# Altium schematic sheet 7404337_RZ_G2.SchDoc
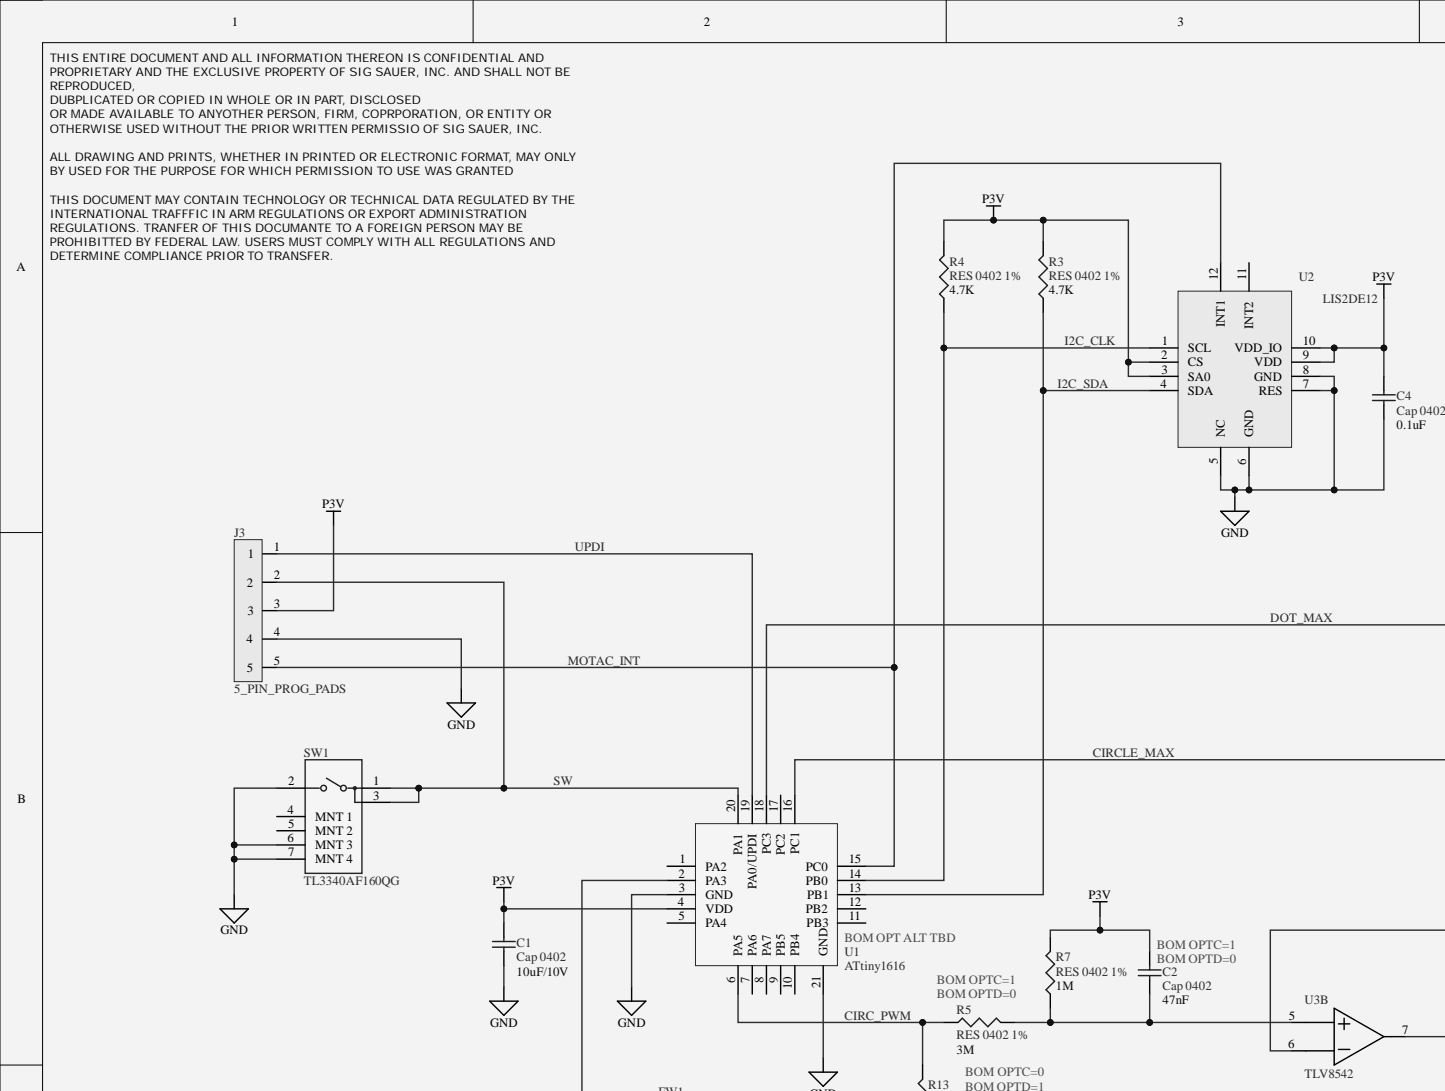
[diagram p0: 7404337_RZ_G2.SchDoc, top left]
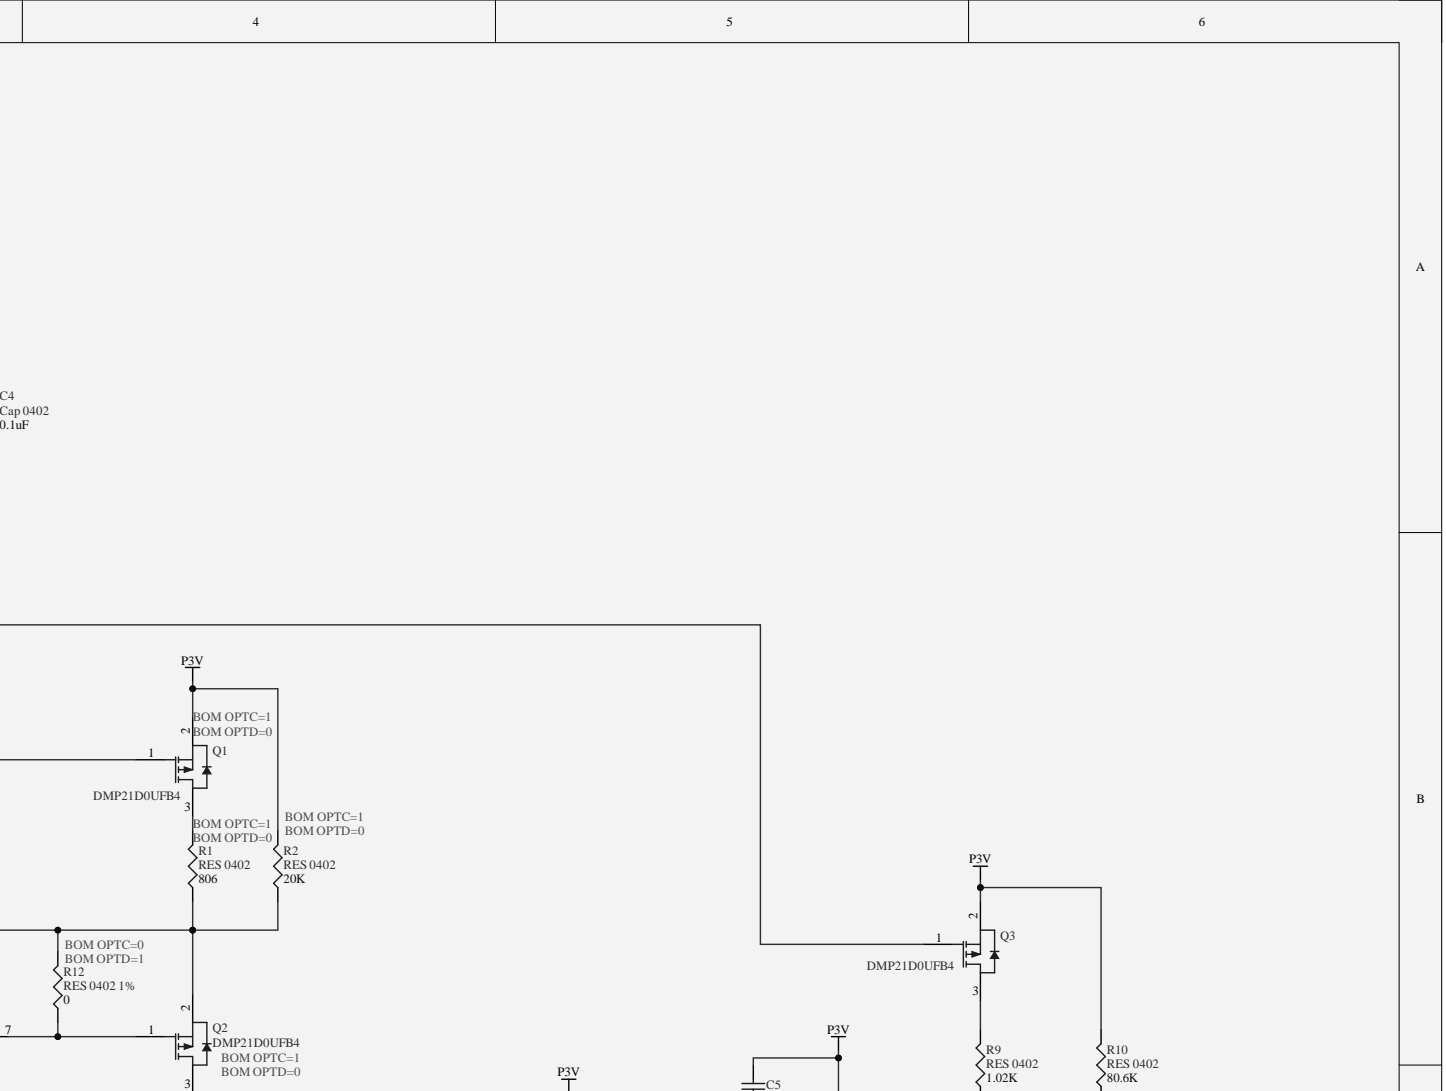
[diagram p1: 7404337_RZ_G2.SchDoc, top right]
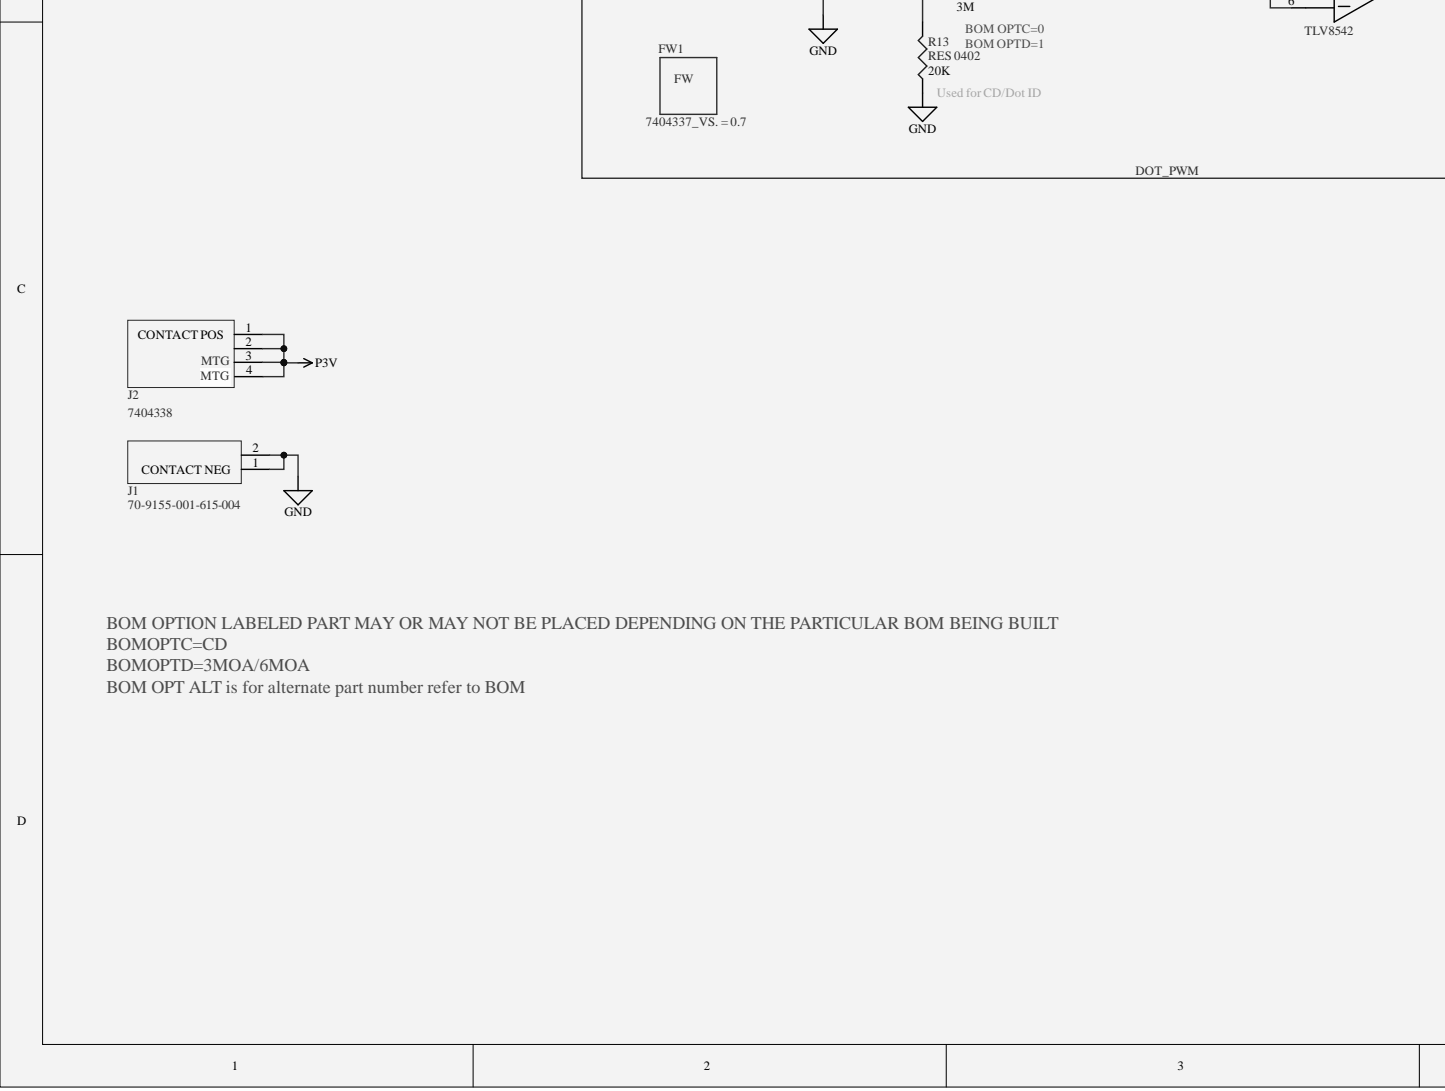
[diagram p2: 7404337_RZ_G2.SchDoc, bottom left]
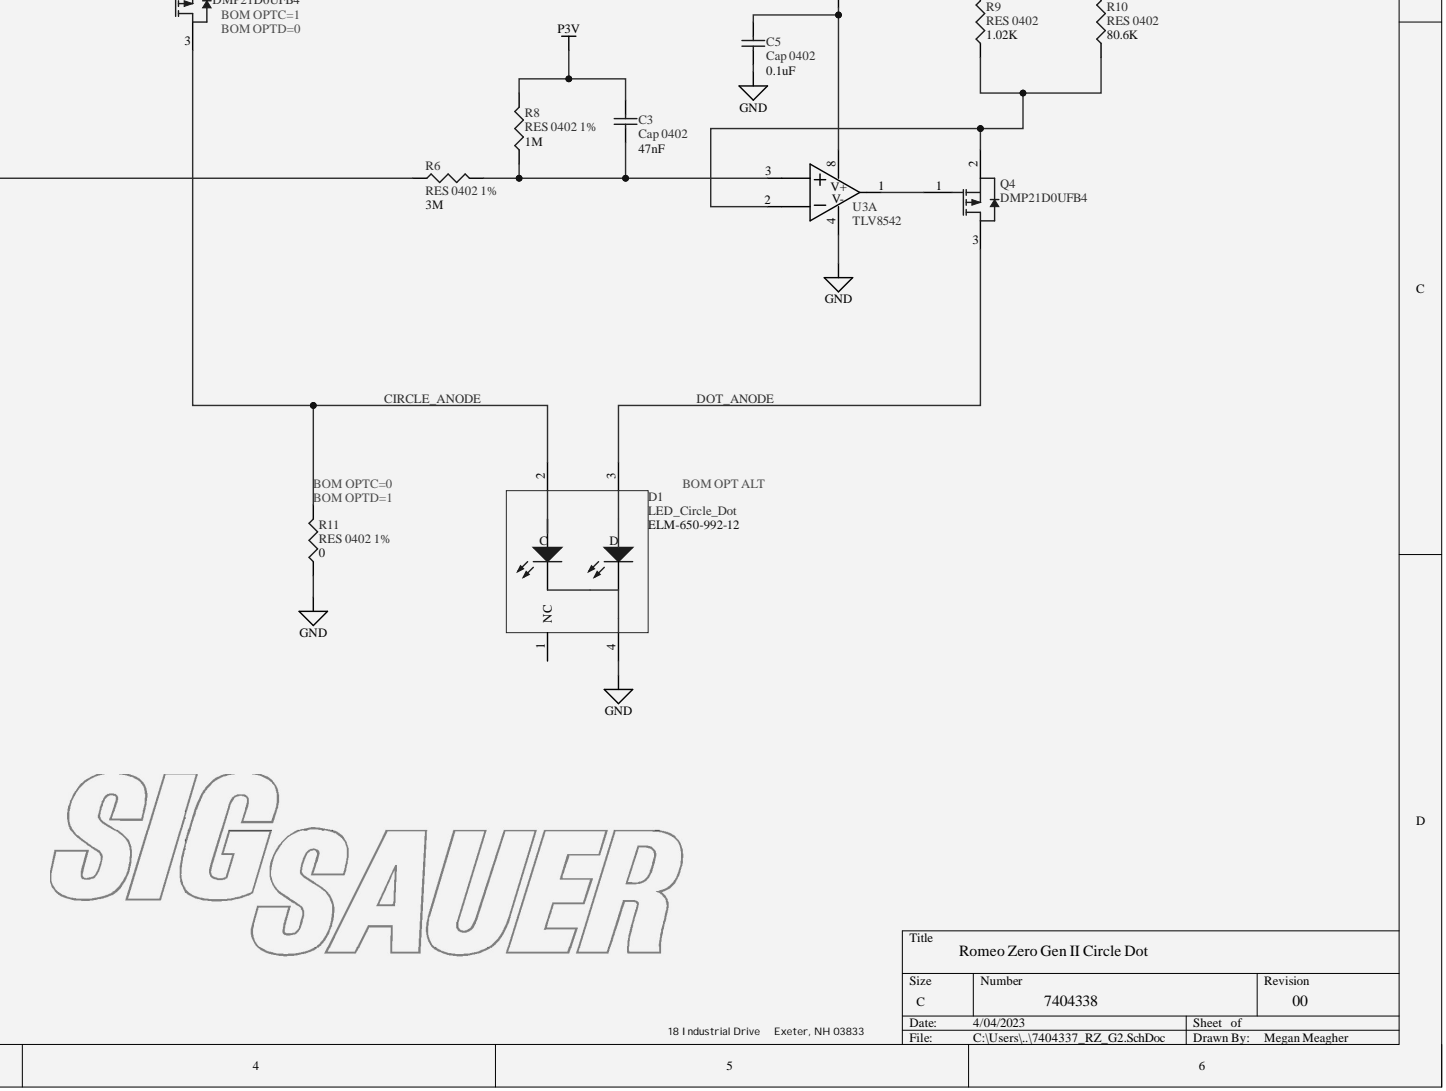
[diagram p3: 7404337_RZ_G2.SchDoc, bottom right]

## Parts
C1  Cap 0402  value 10uF/10V  [C_0402]
C2  Cap 0402  value 47nF  [C_0402]
C3  Cap 0402  value 47nF  [C_0402]
C4  Cap 0402  value 0.1uF  [C_0402]
C5  Cap 0402  value 0.1uF  [C_0402]
D1
D1
D1
D1  LED_Circle_Dot  DPN: ELM-650-992-12  [RZG2_LED_ELM650992-xx_V]
FW1  7404337_VS. = 0.7  (mechanical)
J1  70-9155-001-615-004  [Contact,Single]
J2  7404338  [Contact,RZG2POSBATT]
J3  5_PIN_PROG_PADS  [5pin_pgrm_anchored]
Q1  DMP21D0UFB4  [X2-DFN1006-3]
Q2  DMP21D0UFB4  [X2-DFN1006-3]
Q3  DMP21D0UFB4  [X2-DFN1006-3]
Q4  DMP21D0UFB4  [X2-DFN1006-3]
R1  RES 0402  value 806  [R_0402_2]
R2  RES 0402  value 20K  [R_0402_2]
R3  RES 0402 1%  value 4.7K  [R_0402_2]
R4  RES 0402 1%  value 4.7K  [R_0402_2]
R5  RES 0402 1%  value 3M  [R_0402_2]
R6  RES 0402 1%  value 3M  [R_0402_2]
R7  RES 0402 1%  value 1M  [R_0402_2]
R8  RES 0402 1%  value 1M  [R_0402_2]
R9  RES 0402  value 1.02K  [R_0402_2]
R10  RES 0402  value 80.6K  [R_0402_2]
R11  RES 0402 1%  value 0  [R_0402_2]
R12  RES 0402 1%  value 0  [R_0402_2]
R13  RES 0402  value 20K  [R_0402_2]
SW1  TL3340AF160QG  [FP-TL3340AF160QG-MFG]
U1  ATtiny1616  [VQFN-20 (3mmx3mm)]
U2  LIS2DE12  [LGA12C50P4X4_200X200X100]
U3  TLV8542  [TLV8542_8XFQFNRUG_V]  (parts A,B)

## Nets
GND: C1.1 C4.1 C5.1 D1.4 J1.1 J1.2 J3.4 R11.1 R13.1 SW1.2 SW1.6(MNT_3) SW1.7(MNT_4) U1.3(GND) U1.21(GND) U2.5(NC) U2.6(GND) U2.7(RES) U2.8(GND) U3.4(V-)
P3V: C1.2 C2.2 C3.2 C4.2 C5.2 J2.1(CONTACT_POS) J2.2 J2.3(MTG) J2.4(MTG) J3.3 Q1.2 Q3.2 R2.2 R3.2 R4.2 R7.2 R8.2 R10.2 U1.4(VDD) U2.2(CS) U2.3(SA0) U2.9(VDD) U2.10(VDD_IO) U3.8(V+)
CIRC_PWM: R5.1 R13.2 U1.6(PA5)
CIRCLE_ANODE: D1.2 Q2.3 R11.2
CIRCLE_MAX: Q1.1 U1.16(PC1)
DOT_ANODE: D1.3 Q4.3
DOT_MAX: Q3.1 U1.18(PC3)
DOT_PWM: R6.1 U1.2(PA3)
I2C_CLK: R4.1 U1.14(PB0) U2.1(SCL)
I2C_SDA: R3.1 U1.13(PB1) U2.4(SDA)
MOTAC_INT: J3.5 U1.15(PC0) U2.12(INT1)
SW: J3.2 SW1.1 SW1.3 U1.20(PA1)
UPDI: J3.1 U1.19(PA0/UPDI)
NetC2_1: C2.1 R5.2 R7.1 U3.5
NetC3_1: C3.1 R6.2 R8.1 U3.3
NetQ1_3: Q1.3 R1.2
NetQ2_1: Q2.1 R12.1 U3.7
NetQ2_2: Q2.2 R1.1 R2.1 R12.2 U3.6
NetQ3_3: Q3.3 R9.2
NetQ4_1: Q4.1 U3.1
NetQ4_2: Q4.2 R9.1 R10.1 U3.2

Not connected: D1 1; SW1 4(MNT_1) 5(MNT_2); U1 1(PA2) 5(PA4) 7(PA6) 8(PA7) 9(PB5) 10(PB4) 11(PB3) 12(PB2) 17(PC2); U2 11(INT2)

## Library data of the parts
C1 = Cap: CAP CER 10UF 10V 20% X5R 0402; Manufacturer: Samsung; MPN: CL05A106MP5NUNC; DPN: 1276-1450-2-ND; Component Kind: Standard; Distributor: Digi-key; Library Name: MySchlib.SchLib; Signal Integrity: Cap; Simulation: CAP
C2 C3 = Cap_1: CAP CER 0.047UF 25V X7R 0402 .55mm max; Manufacturer: Murata; MPN: GRM155R71E473KA88D; DPN: 490-3254-2-ND; Component Kind: Standard; Distributor: Digi-key; Library Name: MySchlib.SchLib; Signal Integrity: Cap; Simulation: CAP
C4 C5 = Cap_2: CAP CER 0.1UF 16V X7R 0402; Manufacturer: Murata; MPN: GRM155R71C104KA88D; DPN: 490-3261-2-ND; Component Kind: Standard; Distributor: Digi-key; Library Name: MySchlib.SchLib; Signal Integrity: Cap; Simulation: CAP
D1 = LED_Circle_Dot: Small Circle Dot Module; Manufacturer: Jenoptik; Component Kind: Standard; Distributor: Jenoptik; Library Name: MySchlib.SchLib; MPN: ELM-650-992-12
FW1 = FW: FW for 7404337 Romeo Zero Gen II; Library Name: MySchlib.SchLib
J1 = CONN_BAT_NEG: Battery contact, Kyocera, 70-9155-001-615-004; Value: R0 contact pos; Component Kind: Standard; Library Name: MySchlib.SchLib; Manufacturer: Kyocera; MPN: 70-9155-001-615-004
J2 = CONN_BAT_POS: Sig Custom Battery contact, custom RZGENII CR1632 Positive; MPN: 7401598; Value: R0 contact pos; Component Kind: Standard; Library Name: MySchlib.SchLib; Manufacturer: SIG
J3 = 5_PIN_PROG_PADS: Connector for pwr, gnd, prgm, sw, accel; Component Kind: Standard; Library Name: MySchlib.SchLib
Q1-Q4 = PMV33UPE,215: MOSFET P-CH 20V 770MA 3DFN; Manufacturer: Diodes Incorporated; MPN: DMP21D0UFB4-7B; Distrubutor: Digikey; Component Kind: Standard; DPN: MOSFET P-CH 20V 770MA 3DFN; Library Name: MySchlib.SchLib
R1 = Res3_6: RES SMD 806 OHM 1% 1/16W 0402; Manufacturer: Yageo; MPN: RC0402FR-07806RL; DPN: YAG3239CT-ND; Component Kind: Standard; Distributor: Digi-key; Library Name: MySchlib.SchLib; Simulation: RESISTOR
R2 R13 = Res3_4: RES SMD 20K OHM 1% 1/16W 0402; Manufacturer: Yageo; MPN: RC0402FR-0720KL; DPN: 311-20.0KLRCT-ND; Component Kind: Standard; Distributor: Digi-key; Library Name: MySchlib.SchLib; Simulation: RESISTOR
R3 R4 = Res_4.7k: RES 4.7K OHM 1% 1/16W 0402; Manufacturer: Yageo; MPN: RC0402JR-074K7L; DPN: 311-4.7KJRTR-ND; Component Kind: Standard; Distributor: Digi-key; Library Name: MySchlib.SchLib; Simulation: RESISTOR
R5 R6 = Res3_1: RES 3M OHM 5% 1/16W 0402 100ppm; Manufacturer: Yageo; MPN: RC0402JR-073ML; DPN: 311-3.0MJRTR-ND; Component Kind: Standard; Distributor: Digi-key; Library Name: MySchlib.SchLib; Simulation: RESISTOR
R7 R8 = Res3_2: RES 1M OHM 5% 1/16W 0402 100ppm; Manufacturer: Yageo; MPN: RC0402JR-071ML; DPN: 311-1.0MJRTR-ND; Component Kind: Standard; Distributor: Digi-key; Library Name: MySchlib.SchLib; Simulation: RESISTOR
R9 = Res3_5: RES SMD 1.02K OHM 1% 1/16W 0402; Manufacturer: Yageo; MPN: RC0402FR-071K02L; DPN: YAG3029CT-ND; Component Kind: Standard; Distributor: Digi-key; Library Name: MySchlib.SchLib; Simulation: RESISTOR
R10 = Res3: 80.6 kOhms ±1% 0.063W, 1/16W Chip Resistor 0402; Manufacturer: Yageo; MPN: RC0402FR-0780K6L; DPN: 311-80.6KLRCT-ND; Component Kind: Standard; Distributor: Digi-key; Library Name: MySchlib.SchLib; Simulation: RESISTOR
R11 R12 = Res_0Ohms: RES 0OHM 1% 1/16W 0402; Manufacturer: Yageo; MPN: RC0402JR-070RL; Component Kind: Standard; Distributor: Digi-key; Library Name: MySchlib.SchLib; Simulation: RESISTOR
SW1 = CMP-2000-07251-3: SWITCH TACTILE SPST-NO 0.05A 12V; Actuator Length: 0.85mm; Actuator Material: Polyphthalamide; Actuator Type: Standard; Contact Resistance: 500mR; Current Rating: 50mA; Electrical Life: 100000Cycles; Illumination Type: Non-Illuminated; Operating Force: 160gf; Switch Function: Off-Mom; Switch Travel: 0.2mm; Throw Configuration: SPST; Case/Package: SMD/SMT; Color: White; Component Kind: Standard; Contact Material: Silver; Contact Plating: Stainless Steel; Housing Material: Polyphthalamide; Lead Free: Lead Free; Library Name: Altium Content Vault; Max Operating Temperature: 85°C; Max Voltage Rating (DC): 12V; Mechanical Life: 100000Cycles; Min Operating Temperature: -35°C; Mount: Surface Mount; Orientation: Right Angle; Package Quantity: 1; Packaging: Tape and Reel; REACH SVHC: No SVHC; Termination: Gull Wing; Voltage Rating (DC): 12V
U1 = ATTINT1616: IC MCU 8BIT 16KB FLASH 20VQFN; Manufacturer: Microchip; MPN: ATTINY1616-MNR; DPN: ATTINY1616-MNRCT-ND; Component Kind: Standard; Distributor: Digikey; Library Name: MySchlib.SchLib; Value: ATTINY1616
U2 = LIS2DW12TR_1: Accelerometer X, Y, Z Axis 12VFLGA; Manufacturer: STMicroelectronics; MPN: LIS2DE12TR; DPN: 497-15997-1-ND; Component Kind: Standard; Distributor: Digi-key; Library Name: MySchlib.SchLib
U3 = TLV8542: IC CMOS 2 CIRCUIT 8X2QFN; Manufacturer: Texas Instruments; MPN: TLV8542RUGR; DPN: 296-50282-1-ND; Component Kind: Standard; Distributor: Digikey; Library Name: MySchlib.SchLib; Value: TLV8542
